# Altium schematic sheet Additional Circuits - Handheld - Rev 2.SchDoc("Additional Circuits - Handheld - Rev 2")
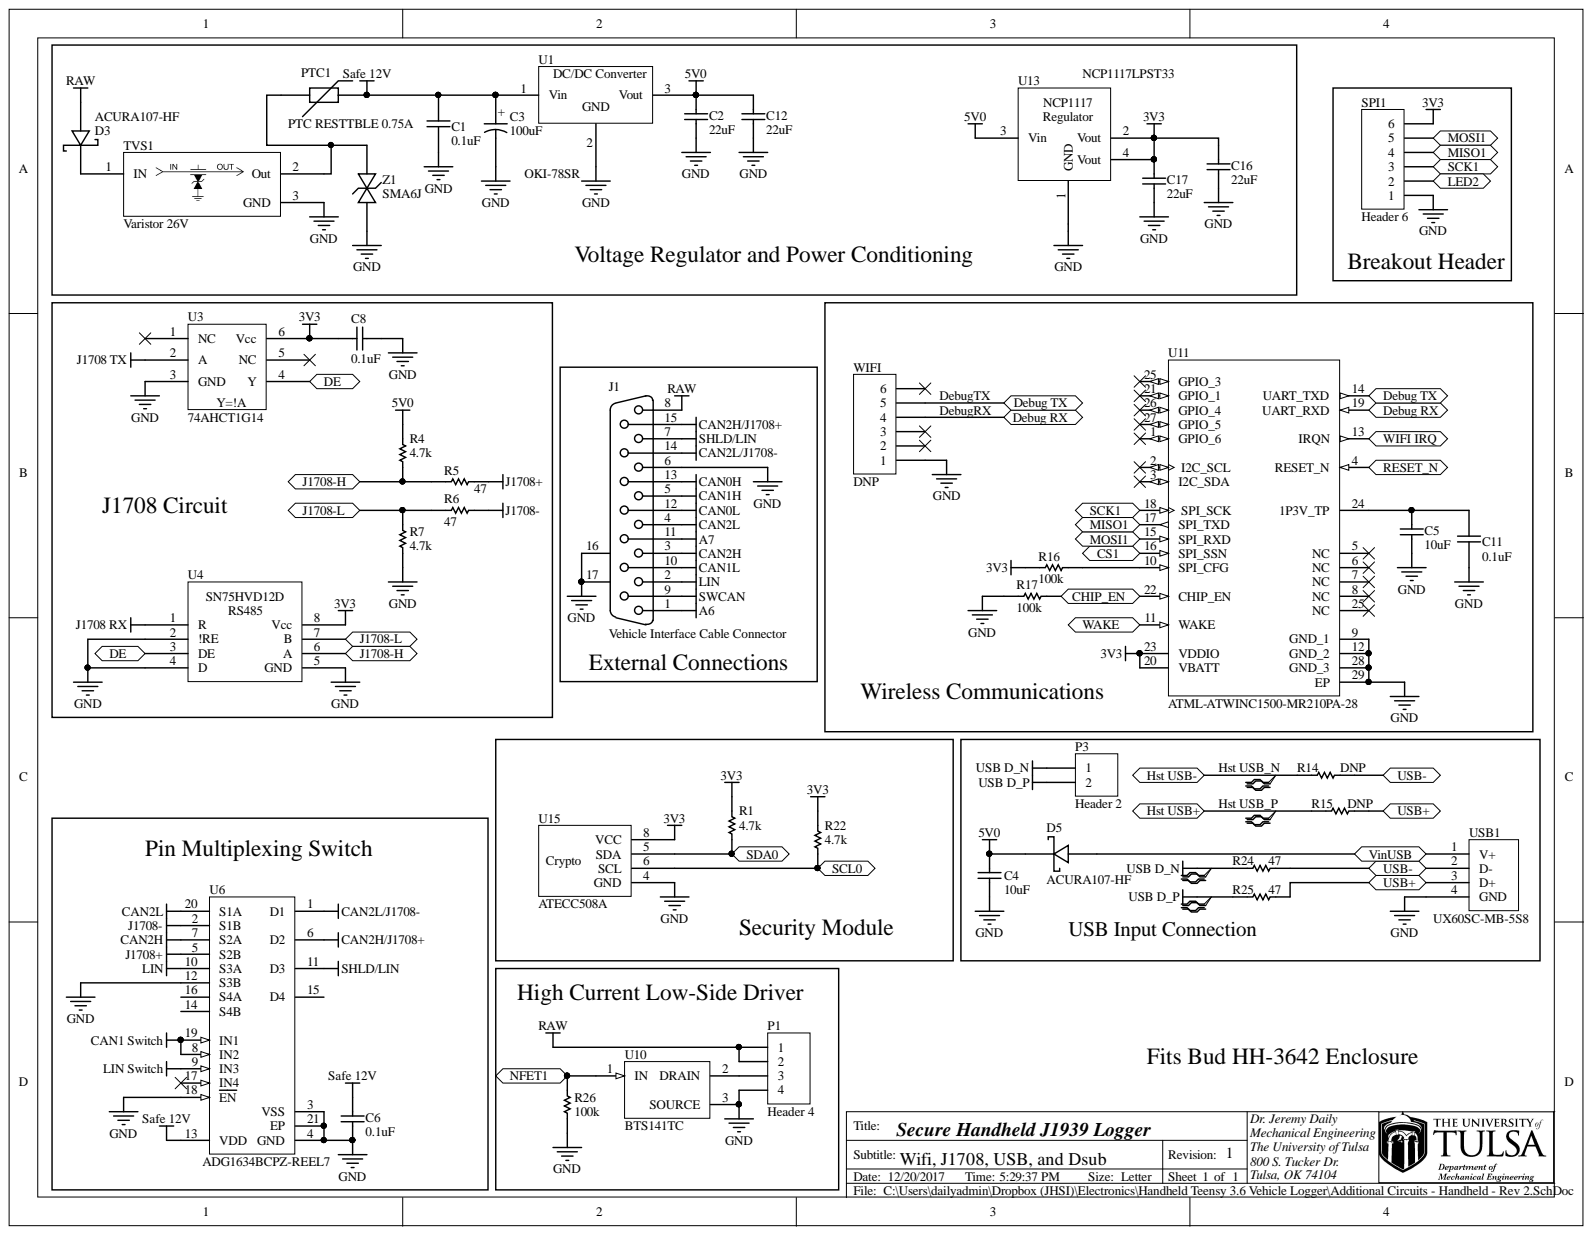
[diagram p0: Additional Circuits - Handheld - Rev 2.SchDoc]

## Parts
C1  0.1uF  [0603]
C2  22uF  [1206]
C3  100uF  [Elec. Cap - G]
C4  10uF  [0603]
C5  10uF  [1206]
C6  0.1uF  [0603]
C8  0.1uF  [0603]
C11  0.1uF  [0603]
C12  22uF  [1206]
C16  22uF  [1206]
C17  22uF  [1206]
D3  ACURA107-HF  [SMA with A and K]
D5  ACURA107-HF  [SMA with A and K]
J1  Vehicle Interface Cable Connector  [DSUB1.385-2H15]
P1  Header 4  [HDR1X4]
P3  Header 2  [HDR1X2]
PTC1  PTC RESTTBLE 0.75A  [1812]
R1  4.7k  [0603]
R4  4.7k  [0603]
R5  47  [0603]
R6  47  [0603]
R7  4.7k  [0603]
R14  DNP  [0603]
R15  DNP  [0603]
R16  100k  [0603]
R17  100k  [0603]
R22  4.7k  [0603]
R24  47  [0603]
R25  47  [0603]
R26  100k  [0603]
SPI1  Header 6  [HDR1X6]
TVS1  Varistor 26V  [Transfeed]
U1  OKI-78SR  [OKI-78SR]
U3  74AHCT1G14  [SOT-353]
U4  SN75HVD08DR  [SOIC-SN8_N]  (value not drawn)
U6  ADG1634BCPZ-REEL7  [ADI-CP-20-4_N]
U10  BTS141TC  [INF-PG-TO263-3-2_N]
U11  ATML-ATWINC1500-MR210PA-28  [ATML-ATWINC1500-MR210PA-28_V]
U13  NCP1117LPST33  [SOT-223]
U15  ATECC508A  [SOIC-8]
USB1  UX60SC-MB-5S8  [USB Mini UX60S]
WIFI  DNP  [HDR1X6]
Z1  SMA6J  [SMA]

## Ports
CHIP_EN, CS1, DE, Debug RX, Debug TX, Hst USB+, Hst USB-, J1708-H, J1708-L, LED2, MISO1, MOSI1, NFET1, RESET_N, SCK1, SCL0, SDA0, USB+, USB-, VinUSB, WAKE, WIFI IRQ

## Nets
3V3: C8.2 C16.2 C17.2 R1.1 R16.2 R22.1 SPI1.6 U3.6(Vcc) U4.8(Vcc) U11.20(VBATT) U11.23(VDDIO) U13.2(Vout) U13.4(Vout) U15.8(VCC)
5V0: C2.2 C4.1 C12.2 D5.2 R4.1 U1.3 U13.3(Vin)
A6: J1.1
A7: J1.11
CAN0H: J1.13
CAN0L: J1.12
CAN1 Switch: U6.8(IN2) U6.19(IN1)
CAN1H: J1.5
CAN1L: J1.10
CAN2H: J1.3 U6.7(S2A)
CAN2H/J1708+: J1.15 U6.6(D2)
CAN2L: J1.4 U6.20(S1A)
CAN2L/J1708-: J1.14 U6.1(D1)
GND: C1.1 C2.1 C3.2 C4.2 C5.1 C6.1 C8.1 C11.1 C12.1 C16.1 C17.1 J1.6 J1.16 J1.17 P1.4 R7.2 R17.2 R26.2 SPI1.1 TVS1.3 U1.2 U3.3(GND) U4.2(!RE) U4.4(D) U4.5(GND) U6.3(VSS) U6.4(GND) U6.12(S3B) U6.18(EN) U6.21(EP) U10.3 U11.9(GND_1) U11.12(GND_2) U11.28(GND_3) U11.29(EP) U13.1(GND) U15.4(GND) USB1.4(GND) WIFI.1 Z1.1
J1708 RX: U4.1(R)
J1708 TX: U3.2(A)
J1708+: R5.2 U6.5(S2B)
J1708-: R6.2 U6.2(S1B)
LIN: J1.2 U6.10(S3A)
LIN Switch: U6.9(IN3)
RAW: D3.1 J1.8 P1.1 P1.2
Safe 12V: C1.2 C3.1 C6.2 PTC1.2 U1.1 U6.13(VDD)
SHLD/LIN: J1.7 U6.11(D3)
SWCAN: J1.9
USB D_N: P3.1 R24.1
USB D_P: P3.2 R25.1
CHIP_EN: R17.1 U11.22(CHIP_EN) ; port CHIP_EN
CS1: U11.16(SPI_SSN) ; port CS1
DE: U3.4(Y) U4.3(DE) ; port DE
DebugRX: U11.19(UART_RXD) WIFI.4 ; port Debug RX
DebugTX: U11.14(UART_TXD) WIFI.5 ; port Debug TX
Hst USB_N: R14.1
Hst USB_P: R15.1
J1708-H: R4.2 R5.1 U4.6(A) ; port J1708-H
J1708-L: R6.1 R7.1 U4.7(B) ; port J1708-L
LED2: SPI1.2 ; port LED2
MISO1: SPI1.4 U11.17(SPI_TXD) ; port MISO1
MOSI1: SPI1.5 U11.15(SPI_RXD) ; port MOSI1
NFET1: R26.1 U10.1 ; port NFET1
RESET_N: U11.4(RESET_N) ; port RESET_N
SCK1: SPI1.3 U11.18(SPI_SCK) ; port SCK1
SCL0: R22.2 U15.6(SCL) ; port SCL0
SDA0: R1.2 U15.5(SDA) ; port SDA0
VinUSB: D5.1 USB1.1(V+) ; port VinUSB
WAKE: U11.11(WAKE) ; port WAKE
WIFI IRQ: U11.13(IRQN) ; port WIFI IRQ
NetC5_2: C5.2 C11.2 U11.24(1P3V_TP)
NetD3_2: D3.2 TVS1.1
NetP1_3: P1.3 U10.2
NetPTC1_1: PTC1.1 TVS1.2 Z1.2
NetR14_2: R14.2 R24.2 USB1.2(D-)
NetR15_2: R15.2 R25.2 USB1.3(D+)
NetR16_1: R16.1 U11.10(SPI_CFG)

Not connected: U3 1(NC) 5(NC); U6 14(S4B) 15(D4) 16(S4A) 17(IN4); U11 1(GPIO_6) 2(I2C_SCL) 3(I2C_SDA) 5(NC) 6(NC) 7(NC) 8(NC) 21(GPIO_1) 25(GPIO_3) 26(GPIO_4) 27(GPIO_5); WIFI 2 3 6

## Library data of the parts
C1 C11 = Cap: CAP CER 0.1UF 50V X7R 0603; PartNumber: GRM188R71H104KA93D; Manufacturer: Murata Electronics North America; Supplier Part Number 1: 490-1519-1-ND; Supplier 1: Digi-Key; Library Name: SSS Integrated Library.IntLib; Component Kind: Standard
C2 C12 C16 C17 = Cap: 22µF ±20% 25V Ceramic Capacitor X5R 1206 (3216 Metric); PartNumber: GRT31CR61E226ME01L; Manufacturer: Murata Electronics North America; Supplier Part Number 1: 490-12451-1-ND; Supplier 1: Digi-Key; Simulation: CAP; Signal Integrity: Cap; Library Name: SSS Integrated Library.IntLib; Component Kind: Standard
C3 = Tantalum Cap_1: Aluminum Electrolytic Capacitors Radial, Can - SMD 5000 Hrs @ 105°C; PartNumber: EEE-HC1H101P; Manufacturer: Panasonic Electronic Components; Supplier Part Number 1: P19732CT-ND; Supplier 1: Digi-Key; Library Name: SSS Schematic Library.SchLib; Component Kind: Standard
C4 = Cap: 10µF ±20% 25V Ceramic Capacitor X5R 0603 (1608 Metric); PartNumber: GRT188R61E106ME13D; Manufacturer: Murata Electronics North America; Supplier Part Number 1: 490-12323-1-ND; Supplier 1: Digi-Key; Simulation: CAP; Signal Integrity: Cap; Library Name: SSS Integrated Library.IntLib; Component Kind: Standard
C5 = Cap: 10µF ±20% 50V Ceramic Capacitor X5R 1206 (3216 Metric); PartNumber: GRT31CR61H106ME01L; Manufacturer: Murata Electronics North America; Supplier Part Number 1: 490-12457-1-ND; Supplier 1: Digi-Key; Simulation: CAP; Signal Integrity: Cap; Library Name: SSS Integrated Library.IntLib; Component Kind: Standard
C6 C8 = Cap: CAP CER 0.1UF 50V 10% X7R 0603; PartNumber: GRM188R71H104KA93D; Manufacturer: Murata Electronics North America; Supplier Part Number 1: 490-1519-1-ND; Supplier 1: Digi-Key; Simulation: CAP; Signal Integrity: Cap; Library Name: SSS Integrated Library.IntLib; Component Kind: Standard
D3 D5 = Schottky Diode: Diode Schottky 1000V 1A Surface Mount DO-214AC (SMA); Manufacturer: Comchip Technology; Supplier Part Number 1: 641-1884-1-ND; Supplier 1: Digi-Key; PartNumber: ACURA107-HF; Library Name: SSS Integrated Library.IntLib; Component Kind: Standard
J1 = D Connector 15: Receptacle Assembly, 15 Position, Right Angle; PartNumber: D15S33E4GV00LF; Manufacturer: Amphenol FCI; Supplier Part Number 1: 609-1498-ND; Supplier 1: Digi-Key; Signal Integrity: Connector; Library Name: Miscellaneous Connectors.IntLib; Component Kind: Standard
P1 = Header 4: Header, 4-Pin; Signal Integrity: Connector; Library Name: Miscellaneous Connectors.IntLib; Component Kind: Standard
P3 = Header 2: Header, 2-Pin; Signal Integrity: Connector; Library Name: Miscellaneous Connectors.IntLib; Component Kind: Standard
PTC1 = PTC: PTC RESTTBLE 0.75A 33V CHIP 1812; PartNumber: 0ZCG0075BF2B; Manufacturer: Bel Fuse Inc.; Supplier Part Number 1: 507-1765-1-ND; Supplier 1: Digi-Key; Library Name: SSS Integrated Library.IntLib; Component Kind: Standard
R1 R4 R7 R22 = Chip Resistor: ±1% 0.1W, 1/10W Chip Resistor 0603 (1608 Metric) Automotive AEC-Q200, High Voltage Thick Film; PartNumber: KTR03EZPF4701; Manufacturer: Rohm Semiconductor; Supplier Part Number 1: RHM4.7KAYCT-ND; Supplier 1: Digi-Key; Library Name: SSS Integrated Library.IntLib; Component Kind: Standard
R5 R6 R24 R25 = Chip Resistor: ±1% 0.1W, 1/10W Chip Resistor 0603 (1608 Metric) Automotive AEC-Q200, High Voltage Thick Film; PartNumber: KTR03EZPF47R0; Manufacturer: Rohm Semiconductor; Supplier Part Number 1: RHM47AYCT-ND; Supplier 1: Digi-Key; Library Name: SSS Integrated Library.IntLib; Component Kind: Standard
R14 R15 = Chip Resistor: RES SMD 47 OHM 1% 1/10W 0603; PartNumber: ERJ-3EKF47R0V; Manufacturer: Panasonic Electronic Components; Supplier Part Number 1: P47.0HCT-ND; Supplier 1: Digi-Key; Library Name: SSS Integrated Library.IntLib; Component Kind: Standard
R16 R17 R26 = Chip Resistor: ±1% 0.1W, 1/10W Chip Resistor 0603 (1608 Metric) Automotive AEC-Q200, High Voltage Thick Film; PartNumber: KTR03EZPF1003; Manufacturer: Rohm Semiconductor; Supplier Part Number 1: RHM100KAYCT-ND; Supplier 1: Digi-Key; Library Name: SSS Integrated Library.IntLib; Component Kind: Standard
SPI1 = Header 6: Header, 6-Pin; Signal Integrity: Connector; Library Name: Miscellaneous Connectors.IntLib; Component Kind: Standard
TVS1 = Transfeed: 34.5V 80A Varistor 1 Circuit Surface Mount 0805 (2012 Metric); PartNumber: V2AF126C600Y2EDP; Manufacturer: AVX; Supplier Part Number 1: 478-10038-1-ND; Supplier 1: Digi-Key; Library Name: TU Custom Parts Schematics.SchLib; Component Kind: Standard
U1 = 7805: 5V DC/DC Converter (7805 replacement); PartNumber: OKI-78SR-5/1.5-W36H-C; Manufacturer: Murata Power Solutions Inc.; Supplier Part Number 1: 811-2692-ND; Supplier 1: Digi-Key; Library Name: SSS Integrated Library.IntLib; Component Kind: Standard
U3 = 74AHCT1G14 Inverter: IC SCHMIT-TRIGG INV GATE SOT353; PartNumber: 74AHCT1G14SE-7; Manufacturer: Diodes Incorporated; Supplier Part Number 1: 74AHCT1G14SE-7DICT-ND; Supplier 1: Digi-Key; Library Name: SSS Schematic Library.SchLib; Component Kind: Standard
U4 = SN75HVD12D (3.3v RS485): IC RS485 TXRX 3/5V 8-SOIC; Manufacturer: Texas Instruments; Supplier Part Number 1: 296-37893-1-ND; Supplier 1: Digi-Key; PartNumber: SN75HVD08DR; Library Name: SSS Integrated Library.IntLib; Component Kind: Standard
U6 = ADG1634BCPZ-REEL7: IC SWITCH QUAD SPDT 20LFCSP; Manufacturer: Analog Devices; Supplier Part Number 1: ADG1634BCPZ-REEL7CT-ND; Supplier 1: Digi-Key; PartNumber: ADG1634BCPZ-REEL7; Library Name: Analog Devices Switches and Multiplexers Analog Switches.IntLib; Component Kind: Standard
U10 = BTS141TC: Smart Low-Side Power Switch HITFET, 60 V VDS, 12 A ID, -40 to 150 degC, PG-TO263-3-2, Reel, Green; Manufacturer: Infineon; Supplier Part Number 1: BTS141TCTR-ND; Supplier 1: Digi-Key; PartNumber: BTS141TC; Library Name: Infineon_Power_Smart_Low-Side_&_High-Side_Switches.IntLib; Component Kind: Standard
U11 = ATML-ATWINC1500-MR210PA-28: SMARTCONNECT ATWINC1500B-MU-T MO; PartNumber: ATWINC1510-MR210PB1140; Manufacturer: Microchip Technologies; Supplier Part Number 1: ATWINC1510-MR210PB1140-ND; Supplier 1: Digi-Key; Library Name: TU Custom Parts Schematics.SchLib; Component Kind: Standard
U13 = NCP1117 2.5V: Linear Voltage Regulator IC Positive Fixed Output 3.3V 1A SOT-223; PartNumber: NCP1117LPST33T3G; Manufacturer: On Semiconductor; Supplier Part Number 1: NCP1117LPST33T3GOSCT-ND; Supplier 1: Digi-Key; Library Name: SSS Integrated Library.IntLib; Component Kind: Standard
U15 = ATECC508A: Authentication Chip IC Networking and Communications 8-SOIC; PartNumber: ATECC508A-SSHDA-T; Manufacturer: Microchip Technology; Supplier Part Number 1: ATECC508A-SSHDA-TCT-ND; Supplier 1: Digi-Key; Library Name: TU Custom Parts Schematics.SchLib; Component Kind: Standard
USB1 = USB-Rugged: USB - mini B USB 2.0 Receptacle Connector 5 Position Surface Mount, Right Angle; Through Hole; Manufacturer: Hirose; Supplier Part Number 1: H11589CT-ND; Supplier 1: Digi-Key; PartNumber: UX60SC-MB-5S8; Library Name: SSS Integrated Library.IntLib; Component Kind: Standard
WIFI = Header 6: CONN HEADER FEMALE 6POS .1" GOLD; PartNumber: PPPC061LFBN-RC; Manufacturer: Sullins Connector Solutions; Supplier Part Number 1: S7039-ND; Supplier 1: Digi-Key; Signal Integrity: Connector; Library Name: Miscellaneous Connectors.IntLib; Component Kind: Standard
Z1 = Zener - Bidirectional: TVS DIODE 20VWM 36.8VC SMA; PartNumber: SMA6J24CA-TP; Manufacturer: Micro Commercial Co; Supplier Part Number 1: SMA6J24CA-TPMSCT-ND; Supplier 1: Digi-Key; Library Name: SSS Integrated Library.IntLib; Component Kind: Standard
